# Altium schematic sheet 6_Peripherial.schdoc(6_Peripherial)
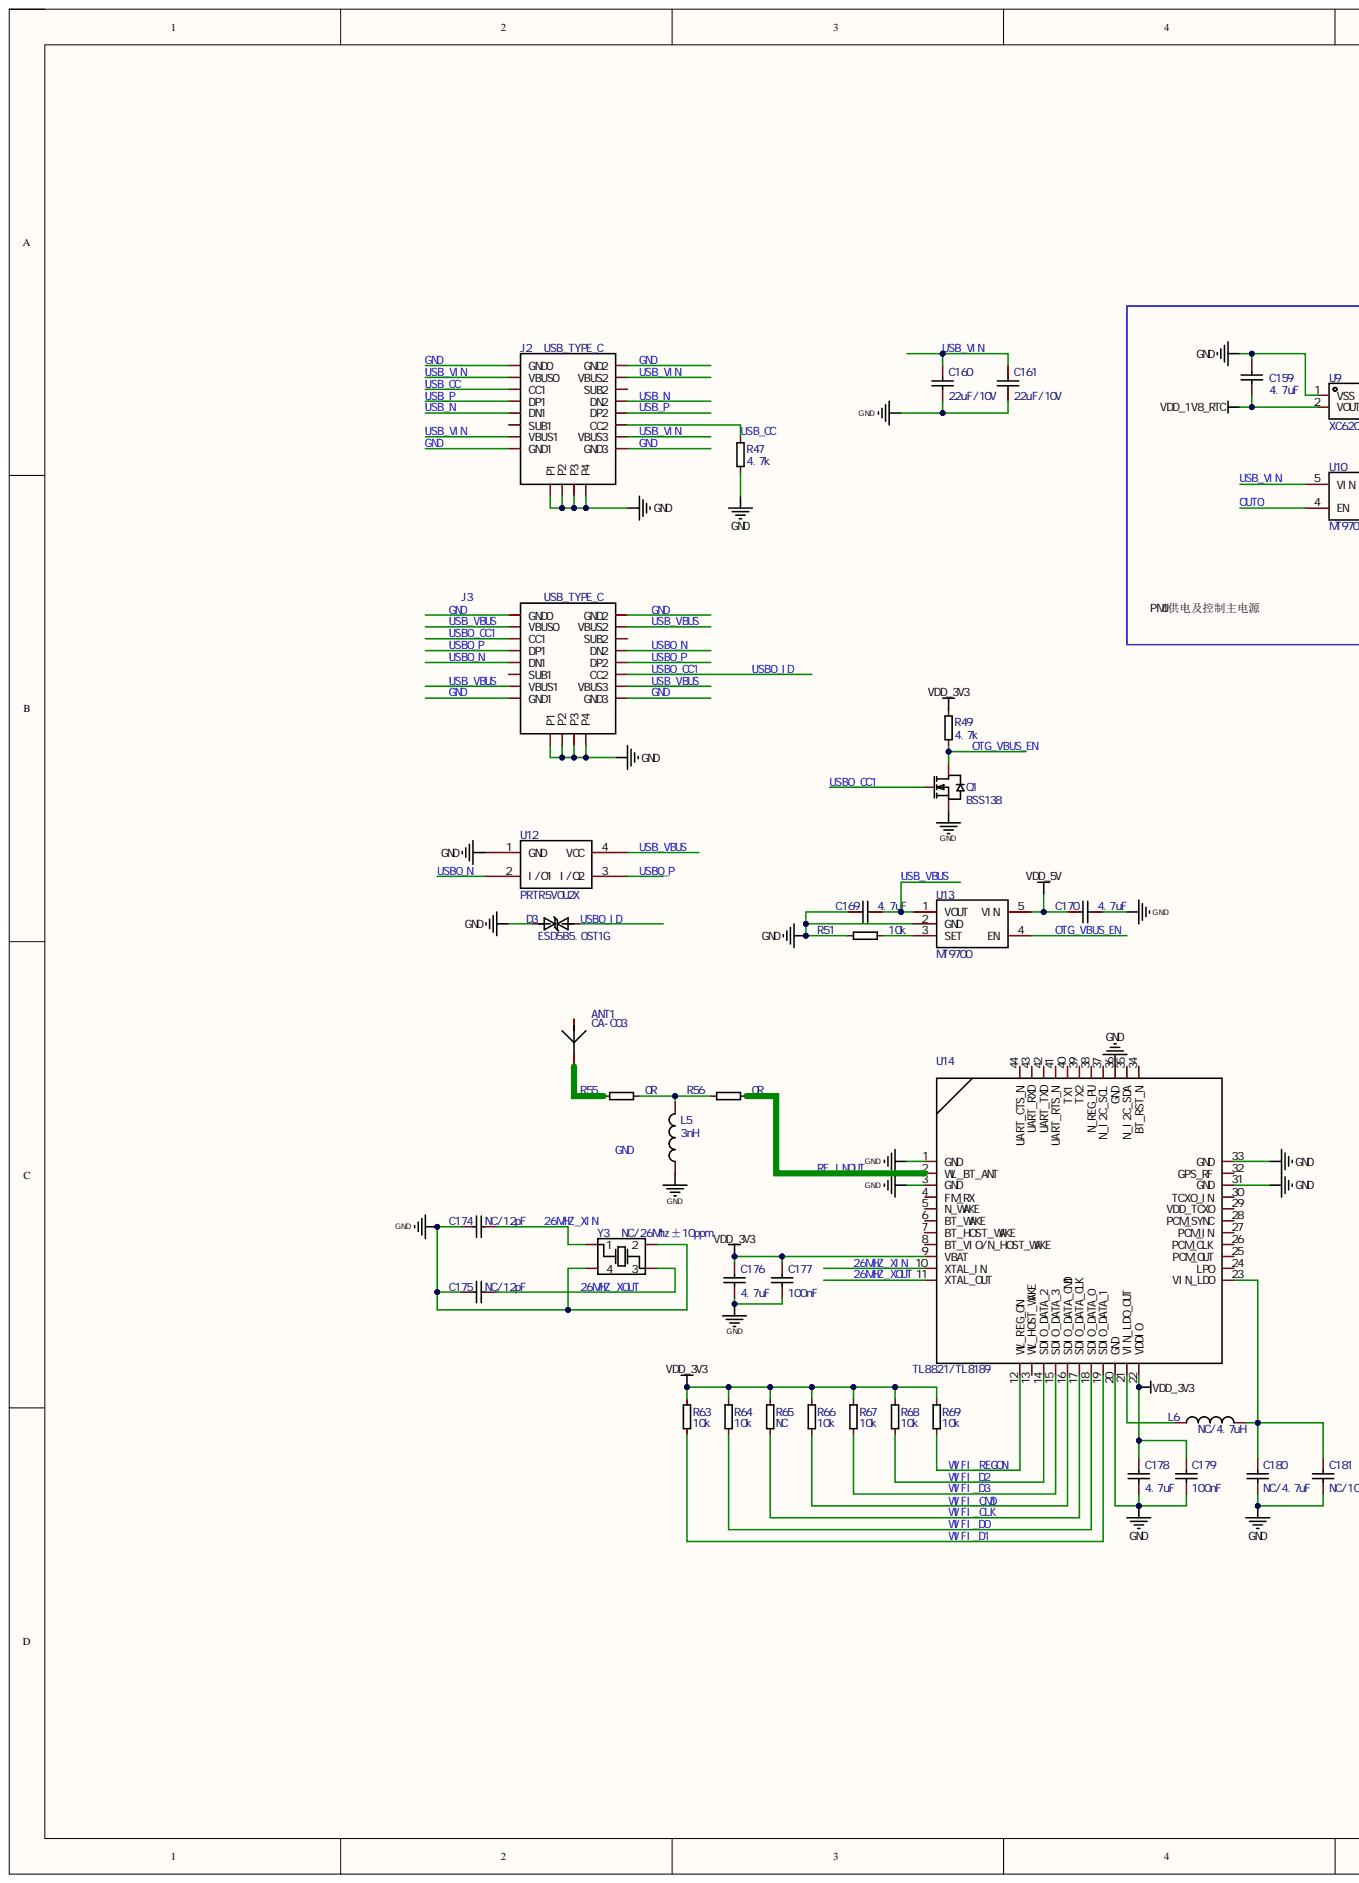
[diagram p0: 6_Peripherial.schdoc, left]
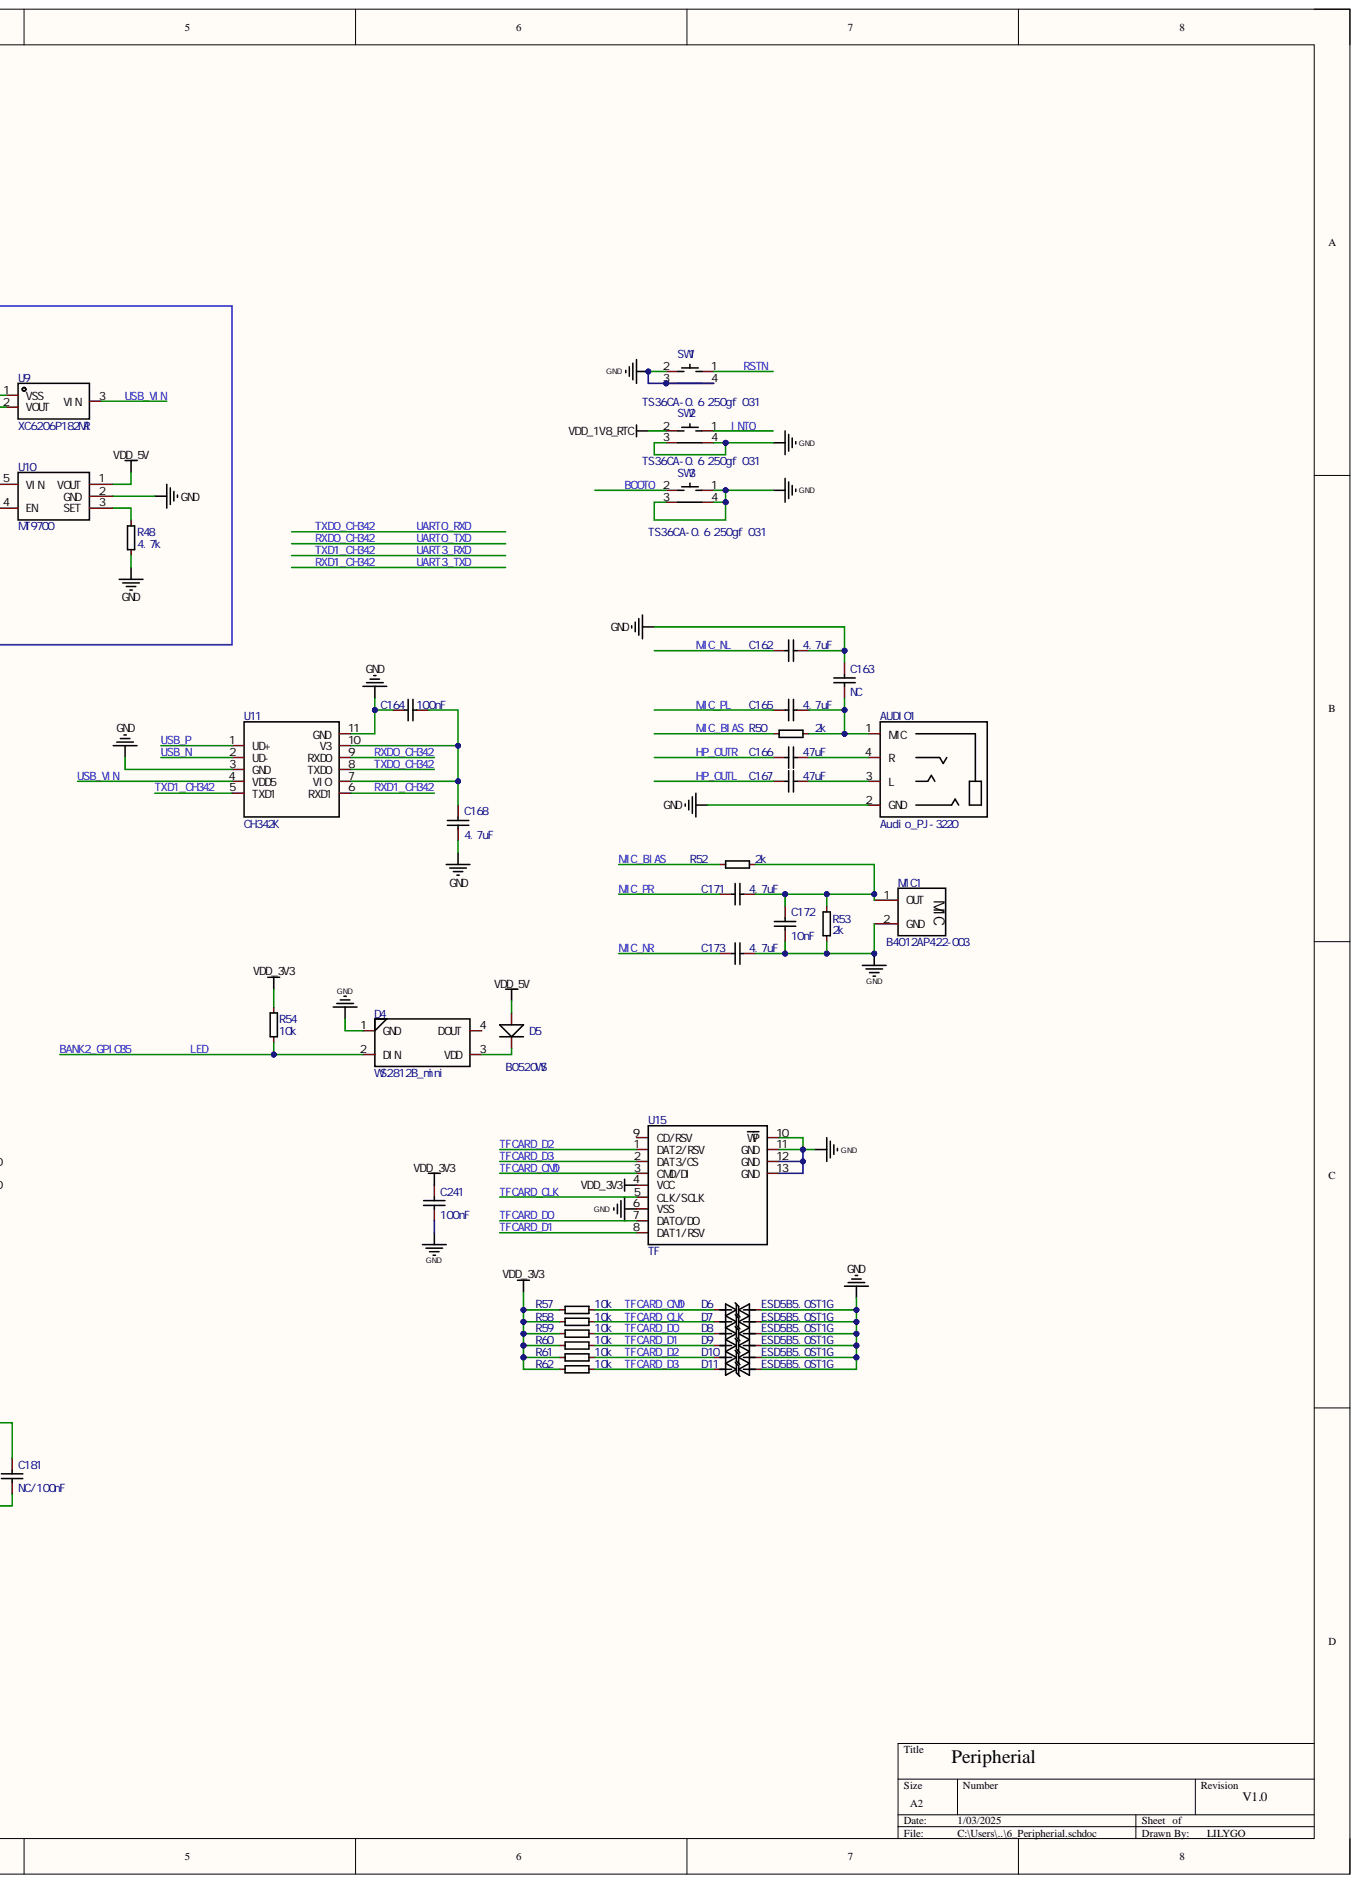
[diagram p1: 6_Peripherial.schdoc, right]

## Parts
ANT1  CA-C03  [ANT-SMD_CA-C03]
AUDIO1  Audio_PJ-3220  [AUDIO_JACK_PJ-3220-Y]
C159  4.7uF  [c0402]
C160  22uF/10V  [c0603]
C161  22uF/10V  [c0603]
C162  4.7uF  [c0402]
C163  NC  [c0402]
C164  100nF  [c0402]
C165  4.7uF  [c0402]
C166  47uF  [C1206]
C167  47uF  [C1206]
C168  4.7uF  [c0402]
C169  4.7uF  [c0402]
C170  4.7uF  [c0402]
C171  4.7uF  [c0402]
C172  10nF  [c0402]
C173  4.7uF  [c0402]
C174  NC/12pF  [c0402]
C175  NC/12pF  [c0402]
C176  4.7uF  [c0402]
C177  100nF  [c0402]
C178  4.7uF  [c0402]
C179  100nF  [c0402]
C180  NC/4.7uF  [c0402]
C181  NC/100nF  [c0402]
C241  100nF  [c0402]
D3  ESD5B5.0ST1G  [SOD-523]
D4  WS2812B_mini  [LED-SMD_4P-L3.5-W3.5-TL_WS2812B]
D5  B0520WS  [SOD-523]
D6  ESD5B5.0ST1G  [SOD-523]
D7  ESD5B5.0ST1G  [SOD-523]
D8  ESD5B5.0ST1G  [SOD-523]
D9  ESD5B5.0ST1G  [SOD-523]
D10  ESD5B5.0ST1G  [SOD-523]
D11  ESD5B5.0ST1G  [SOD-523]
J2  USB_TYPE_C  [USB_TYPE_C]
J3  USB_TYPE_C  [USB_TYPE_C]
L5  3nH  [r0402]
L6  NC/4.7uH  [252012_NSK]
MIC1  B4012AP422-003  [MIC-TH_BD4.0-P1.40-D0.3-L]
Q1  BSS138  [SOT-23-3_L2.9-W1.3-P1.90-LS2.4-BR]
R47  4.7k  [r0402]
R48  4.7k  [r0402]
R49  4.7k  [r0402]
R50  2k  [r0402]
R51  10k  [r0402]
R52  2k  [r0402]
R53  2k  [r0402]
R54  10k  [r0402]
R55  0R  [r0402]
R56  0R  [r0402]
R57  10k  [r0402]
R58  10k  [r0402]
R59  10k  [r0402]
R60  10k  [r0402]
R61  10k  [r0402]
R62  10k  [r0402]
R63  10k  [r0402]
R64  10k  [r0402]
R65  NC  [r0402]
R66  10k  [r0402]
R67  10k  [r0402]
R68  10k  [r0402]
R69  10k  [r0402]
SW1  TS36CA-0.6 250gf 031  [SW-SMD_SHOU_TS36CA-0.6]
SW2  TS36CA-0.6 250gf 031  [SW-SMD_SHOU_TS36CA-0.6]
SW3  TS36CA-0.6 250gf 031  [SW-SMD_SHOU_TS36CA-0.6]
U9  XC6206P182MR  [SOT-23-3_L3.0-W1.7-P0.95-LS2.9-BR]
U10  MT9700  [SOT-23-5_L3.0-W1.7-P0.95-LS2.8-BR]
U11  CH342K  [ESSOP-10-EP]
U12  PRTR5V0U2X  [SOT143L]
U13  MT9700  [SOT-23-5_L3.0-W1.7-P0.95-LS2.8-BR]
U14  TL8821/TL8189  [qfn44-0.9mm-12x12mm]
U15  TF  [TF2]
Y3  NC/26Mhz±10ppm  [XTAL_3225]

## Nets
VDD_1V8_RTC: C159.2 SW2.2 U9.2
VDD_3V3: C176.1 C177.1 C178.1 C179.1 C241.1 R49.1 R54.1 R57.1 R58.1 R59.1 R60.1 R61.1 R62.1 R63.1 R64.1 R65.1 R66.1 R67.1 R68.1 R69.1 U14.9(VBAT) U14.22(VDDIO) U15.4(VCC)
VDD_5V: C170.2 D5.1 U10.1(VOUT) U13.5(VIN)
26MHZ_XIN: C174.2 U14.10(XTAL_IN) Y3.1
26MHZ_XOUT: C175.2 U14.11(XTAL_OUT) Y3.3
BANK2_GPIO35: D4.2(DIN) R54.2
BOOT0: SW3.2
GND: AUDIO1.2(GND) C159.1 C160.2 C161.2 C162.2 C163.1 C164.1 C168.2 C169.2 C170.1 C172.2 C173.2 C174.1 C175.1 C176.2 C177.2 C178.2 C179.2 C180.2 C181.2 C241.2 D3.2 D4.1(GND) D6.2 D7.2 D8.2 D9.2 D10.2 D11.2 J2.A1(GND0) J2.A12(GND1) J2.B1(GND3) J2.B12(GND2) J2.P1 J2.P2 J2.P3 J2.P4 J3.A1(GND0) J3.A12(GND1) J3.B1(GND3) J3.B12(GND2) J3.P1 J3.P2 J3.P3 J3.P4 L5.1 MIC1.2 Q1.2 R47.2 R48.2 R51.1 R53.2 SW1.2 SW1.3 SW1.4 SW2.3 SW2.4 SW3.1 SW3.3 SW3.4 U9.1 U10.2(GND) U11.3(GND) U11.11(GND) U12.1(GND) U13.2(GND) U14.1(GND) U14.3(GND) U14.20(GND) U14.31(GND) U14.33(GND) U14.36(GND) U15.6(VSS) U15.10(WP) U15.11(GND) U15.12(GND) U15.13(GND) Y3.2 Y3.4
HP_OUTL: C167.1
HP_OUTR: C166.1
INT0: SW2.1
MIC_BIAS: R50.1 R52.1
MIC_NL: C162.1
MIC_NR: C173.1
MIC_PL: C165.1
MIC_PR: C171.1
OTG_VBUS_EN: Q1.3 R49.2 U13.4(EN)
OUT0: U10.4(EN)
RF_INOUT: R56.2 U14.2(WL_BT_ANT)
RSTN: SW1.1
RXD0_CH342: U11.9(RXD0)
RXD1_CH342: U11.6(RXD1)
TFCARD_CLK: D7.1 R58.2 U15.5(CLK/SCLK)
TFCARD_CMD: D6.1 R57.2 U15.3(CMD/DI)
TFCARD_D0: D8.1 R59.2 U15.7(DAT0/DO)
TFCARD_D1: D9.1 R60.2 U15.8(DAT1/RSV)
TFCARD_D2: D10.1 R61.2 U15.1(DAT2/RSV)
TFCARD_D3: D11.1 R62.2 U15.2(DAT3/CS)
TXD0_CH342: U11.8(TXD0)
TXD1_CH342: U11.5(TXD1)
USB0_CC1: D3.1 J3.A5(CC1) J3.B5(CC2) Q1.1
USB0_N: J3.A7(DN1) J3.B7(DN2) U12.2(I/O1)
USB0_P: J3.A6(DP1) J3.B6(DP2) U12.3(I/O2)
USB_CC: J2.A5(CC1) J2.B5(CC2) R47.1
USB_N: J2.A7(DN1) J2.B7(DN2) U11.2(UD-)
USB_P: J2.A6(DP1) J2.B6(DP2) U11.1(UD+)
USB_VBUS: C169.1 J3.A4(VBUS0) J3.A9(VBUS1) J3.B4(VBUS3) J3.B9(VBUS2) U12.4(VCC) U13.1(VOUT)
USB_VIN: C160.1 C161.1 J2.A4(VBUS0) J2.A9(VBUS1) J2.B4(VBUS3) J2.B9(VBUS2) U9.3 U10.5(VIN) U11.4(VDD5)
WIFI_CLK: R65.2 U14.17(SDIO_DATA_CLK)
WIFI_CMD: R66.2 U14.16(SDIO_DATA_CMD)
WIFI_D0: R64.2 U14.18(SDIO_DATA_0)
WIFI_D1: R63.2 U14.19(SDIO_DATA_1)
WIFI_D2: R68.2 U14.14(SDIO_DATA_2)
WIFI_D3: R67.2 U14.15(SDIO_DATA_3)
WIFI_REGON: R69.2 U14.12(WL_REG_ON)
NetANT1_2: ANT1.2 R55.1
NetAUDIO1_1: AUDIO1.1(MIC) C163.2 C165.2 R50.2
NetAUDIO1_3: AUDIO1.3(L) C167.2
NetAUDIO1_4: AUDIO1.4(R) C166.2
NetC164_2: C164.2 C168.1 U11.7(VIO) U11.10(V3)
NetC171_2: C171.2 C172.1 MIC1.1 R52.2 R53.1
NetC180_1: C180.1 C181.1 L6.2 U14.23(VIN_LDO)
NetD4_3: D4.3(VDD) D5.2
NetL5_2: L5.2 R55.2 R56.1
NetL6_1: L6.1 U14.21(VIN_LDO_OUT)
NetR48_1: R48.1 U10.3(SET)
NetR51_2: R51.2 U13.3(SET)

Not connected: ANT1 1; D4 4(DOUT); J2 A8(SUB1) B8(SUB2); J3 A8(SUB1) B8(SUB2); U14 4(FM_RX) 5(N_WAKE) 6(BT_WAKE) 7(BT_HOST_WAKE) 8(BT_VIO/N_HOST_WAKE) 13(WL_HOST_WAKE) 24(LPO) 25(PCM_OUT) 26(PCM_CLK) 27(PCM_IN) 28(PCM_SYNC) 29(VDD_TCXO) 30(TCXO_IN) 32(GPS_RF) 34(BT_RST_N) 35(N_I2C_SDA) 37(N_I2C_SCL) 38(N_REG_PU) 39(TX2) 40(TX1) 41(UART_RTS_N) 42(UART_TXD) 43(UART_RXD) 44(UART_CTS_N); U15 9(CD/RSV)

## Library data of the parts
ANT1 = CA-C03: Add into BOM: yes; Comment_1: CA-C03; Component Kind: Standard; Convert to PCB: yes; Datasheet: 2021-06-05/34112A/eaa7f794ae26492fadde70fdd3fe967f/CA-C03应用手册.pdf; Device: CA-C03; LCSC Part Name: CA-C03; Manufacturer Part: CA-C03; PCB3D: ANT-SMD_CA-C03; Supplier: LCSC; Supplier Footprint: 5520; Supplier Part: C2838842; Symbol: CA-C03
AUDIO1 = Audio_PJ-3220: audio jack; Add into BOM: yes; LC_CODE: C692442; Comment_1: Audio_PJ-3220; Component Kind: Standard; Convert to PCB: yes; Design Item ID: Audio_PJ-3220; Device: Audio_PJ-3220; Library Name: ZX_MyLib; Origin Footprint: AUDIO_JACK_PJ-3220-Y; Symbol: Audio_PJ-3220
C159-C181 = CAP: Add into BOM: yes; Component Kind: Standard; Convert to PCB: yes; Device: CAP; Symbol: CAP
  C159 C162 C165 C168-C171 C173 C176 C178: Comment_1: 4.7uF
  C160 C161: Comment_1: 22uF/10V
  C163: Comment_1: NC
  C164 C177 C179: Comment_1: 100nF
  C166 C167: Comment_1: 47uF
  C172: Comment_1: 10nF
  C174 C175: Comment_1: NC/12pF
  C180: Comment_1: NC/4.7uF
  C181: Comment_1: NC/100nF
C241 = CAP: Add into BOM: yes; Comment_1: NC/100nF; Component Kind: Standard; Convert to PCB: yes; Device: CAP; Symbol: CAP
D3 D6-D11 = DIODE_TVS_B_SOD_523: Part Number: A1508000002; Add into BOM: yes; Origin Footprint 2: SMT_DIODE_SOD_523; Comment_1: ESD5B5.0ST1G; Component Kind: Standard; Convert to PCB: yes; Device: DIODE_TVS_B_SOD_523; Symbol: DIODE_TVS_B_SOD_523; Value: ESD5B5.0ST1G
D4 = WS2812B_B: Add into BOM: yes; Comment_1: WS2812B_mini; Component Kind: Standard; Convert to PCB: yes; Device: WS2812B_B; PCB3D: LED_WS281B_3535; Symbol: WS2812B_B
D5 = Diode: Add into BOM: yes; Comment_1: B0520WS; Component Kind: Standard; Convert to PCB: yes; Device: Diode; Symbol: Diode
J2 J3 = USB_TYPE_C: Add into BOM: yes; Symbol: USB_TYPE_C_16P; Comment_1: USB_TYPE_C; Component Kind: Standard; Convert to PCB: yes; Device: USB_TYPE_C; PCB3D: USB-SMD_TYPE-C-16PIN
L5 L6 = Inductor: Add into BOM: yes; Component Kind: Standard; Convert to PCB: yes; Device: Inductor; PCB3D: inductor_3x3mm; Symbol: Inductor
  L5: Comment_1: 3nH
  L6: Comment_1: NC/4.7uH
MIC1 = B4012AP422-003: Manufacturer: Goertek(歌尔); Add into BOM: yes; Comment_1: B4012AP422-003; Component Kind: Standard; Convert to PCB: yes; Datasheet: https://atta.szlcsc.com/upload/public/pdf/source/20180813/C233791_EB931036CBE94BDAE55CF8920DD0A876.pdf; Device: B4012AP422-003; JLCPCB Part Class: 扩展库; LCSC Part Name: 驻极体电容φ4.0*1.2mm 1.1V-10V 灵敏度-42dB; Manufacturer Part: B4012AP422-003; PCB3D: MIC-TH_BD4.0-H1.2-P1.40; Supplier: LCSC; Supplier Footprint: 插件; Supplier Part: C233791; Symbol: B4012AP422-003
Q1 = BSS138_C400505: 类型:N沟道;漏源电压(Vdss):50V;连续漏极电流(Id):340mA;功率(Pd):360mW;导通电阻(RDS(on)@Vgs,Id):2.5Ω@10V,300mA;; Manufacturer: YANGJIE(扬杰); Add into BOM: yes; Continuous Drain Current (Id): 340mA; Drain Source Voltage (Vdss): 50V; Gate Threshold Voltage (Vgs(th)@Id): 1.2V@250uA; Power Dissipation (Pd): 360mW; Type: N沟道; Comment_1: BSS138; Component Kind: Standard; Convert to PCB: yes; Datasheet: https://atta.szlcsc.com/upload/public/pdf/source/20190626/C400505_FE75FEF90CE41A03BE6F9CFDB099470C.pdf; Device: BSS138_C400505; Drain Source On Resistance (RDS(on)@Vgs,Id): 2.5Ω@10V,300mA; Input Capacitance (Ciss@Vds): -; JLCPCB Part Class: 扩展库; LCSC Part Name: N沟道 50V 340mA; Manufacturer Part: BSS138; Operating Temperature: -; PCB3D: SOT-23-3P_L2.9-W1.3-H1.0-LS2.4-P0.95; Reverse Transfer Capacitance (Crss@Vds): -; Supplier: LCSC; Supplier Footprint: SOT-23; Supplier Part: C400505; Symbol: BSS138_C400505; Total Gate Charge (Qg@Vgs): -
R47-R69 = RES: Add into BOM: yes; Component Kind: Standard; Convert to PCB: yes; Device: RES; Symbol: RES
  R47-R49: Comment_1: 4.7k
  R50 R52 R53: Comment_1: 2k
  R51 R54 R57-R64 R66-R69: Comment_1: 10k
  R55 R56: Comment_1: 0R
  R65: Comment_1: NC
SW1-SW3 = TS36CA-0.6 250gf 031: 触点电流:50mA;额定电压(DC):12V;绝缘电阻:100MΩ;电路结构:单刀单掷;安装方式:卧贴;按钮形状:矩形按钮;按钮/盖帽颜色:黑色;作用力:250gf@±50gf;机械寿命:20000;工作温度:-20℃~+70℃;引脚样式:SMD接片;开关高度:3.5mm;; Manufacturer: SHOU HAN(首韩); Actuator Style: 矩形按钮; Actuator/Cap Color: 黑色; Add into BOM: yes; Circuit: 单刀单掷; Contact Current: 50mA; Insulation Resistance: 100MΩ; Mechanical Life: 20000; Pin Style: SMD接片; Switch Height: 3.5mm; Voltage Rating (DC): 12V; Comment_1: TS36CA-0.6 250gf 031; Component Kind: Standard; Convert to PCB: yes; Datasheet: https://atta.szlcsc.com/upload/public/pdf/source/20211019/6ADEB9DDDDC9A3F33622EA615D016ED7.pdf; Device: TS36CA-0.6 250gf 031; JLCPCB Part Class: 扩展库; LCSC Part Name: 轻触开关 3*6*3.5侧按 直径0.6定位柱 白体黑头 250力度; Manufacturer Part: TS36CA-0.6 250gf 031; Mounting Style: 卧贴; Operating Force: 250gf@±50gf; Operating Temperature: -20℃~+70℃; PCB3D: SW-SMD_SHOU_TS36CA-0.6; Supplier: LCSC; Supplier Footprint: SMD; Supplier Part: C2906288; Symbol: TS36CA-0.6 250GF 031
U9 = XC6206P182MR_C347373: 输出类型:固定;最大输入电压:8V;输出电压:1.8V;输出电流:250mA;电源纹波抑制比(PSRR):40dB@(1kHz);输出极性:正;; Manufacturer: UMW(友台半导体); Add into BOM: yes; Dropout Voltage: 280mV@(50mA); Maximum Input Voltage: 8V; Number of Outputs: 1; Output Current: 250mA; Output Polarity: 正; Output Type: 固定; Output Voltage: 1.8V; Comment_1: XC6206P182MR; Component Kind: Standard; Convert to PCB: yes; Datasheet: https://atta.szlcsc.com/upload/public/pdf/source/20231109/6B10DA60E52FC900BF97E3EFEB5D6341.pdf; Device: XC6206P182MR_C347373; Feature: -; JLCPCB Part Class: 扩展库; LCSC Part Name: 输入8V 输出1.8V 250mA; Manufacturer Part: XC6206P182MR; Noise: -; Operating Temperature: -; PCB3D: SOT-23-3L_L2.9-W1.6-H1.1-LS2.8-P0.95; Power Supply Rejection Ratio (PSRR): 40dB@(1kHz); Quiescent Current (Ground Current): -; Supplier: LCSC; Supplier Footprint: SOT-23; Supplier Part: C347373; Symbol: XC6206P182MR_C347373
U10 U13 = MT9700: 低功耗负载开关/USB电源开关/限流保护开关; Manufacturer: 西安航天民芯; Add into BOM: yes; Comment_1: MT9700; Component Kind: Standard; Convert to PCB: yes; Datasheet: https://atta.szlcsc.com/upload/public/pdf/source/20170418/1492481333031.pdf; Device: MT9700; JLCPCB Part Class: 扩展库; LCSC Part Name: MT9700; Manufacturer Part: MT9700; PCB3D: SOT-23-5_L2.9-W1.6-H1.1-LS2.8-P0.95; Supplier: LCSC; Supplier Footprint: SOT-23-5; Supplier Part: C89855; Symbol: MT9700
U11 = CH342K: Add into BOM: yes; Comment_1: CH342K; Component Kind: Standard; Convert to PCB: yes; Device: CH342K; Symbol: CH342K
U12 = PRTR5V0U2X: Part Number: A0412000073; Add into BOM: yes; LC_CODE: C2827688; Origin Footprint 2: sot143m; Origin Footprint 3: sot143n; Comment_1: PRTR5V0U2X; Component Kind: Standard; Convert to PCB: yes; Description: PRTR5V0U2X; Design Item ID: PRTR5V0U2X; Device: PRTR5V0U2X; Library Name: ZX_MyLib111; Origin Footprint 1: SOT143L; Symbol: PRTR5V0U2X
U14 = AP6212: Add into BOM: yes; Comment_1: TL8821/TL8189; Component Kind: Standard; Convert to PCB: yes; Device: AP6212; Symbol: AP6212
U15 = TF: Part Number: A1503000013; Add into BOM: yes; Value: TF卡座; Comment_1: TF; Component Kind: Standard; Convert to PCB: yes; Device: TF; Origin Footprint 2: TF2; Symbol: TF; Library Name: WendyLib.SchLib
Y3 = CRYSTAL2: Add into BOM: yes; Comment_1: NC/26Mhz±10ppm; Component Kind: Standard; Convert to PCB: yes; Device: CRYSTAL2; Symbol: CRYSTAL2
